# Altium schematic sheet power supply.SchDoc
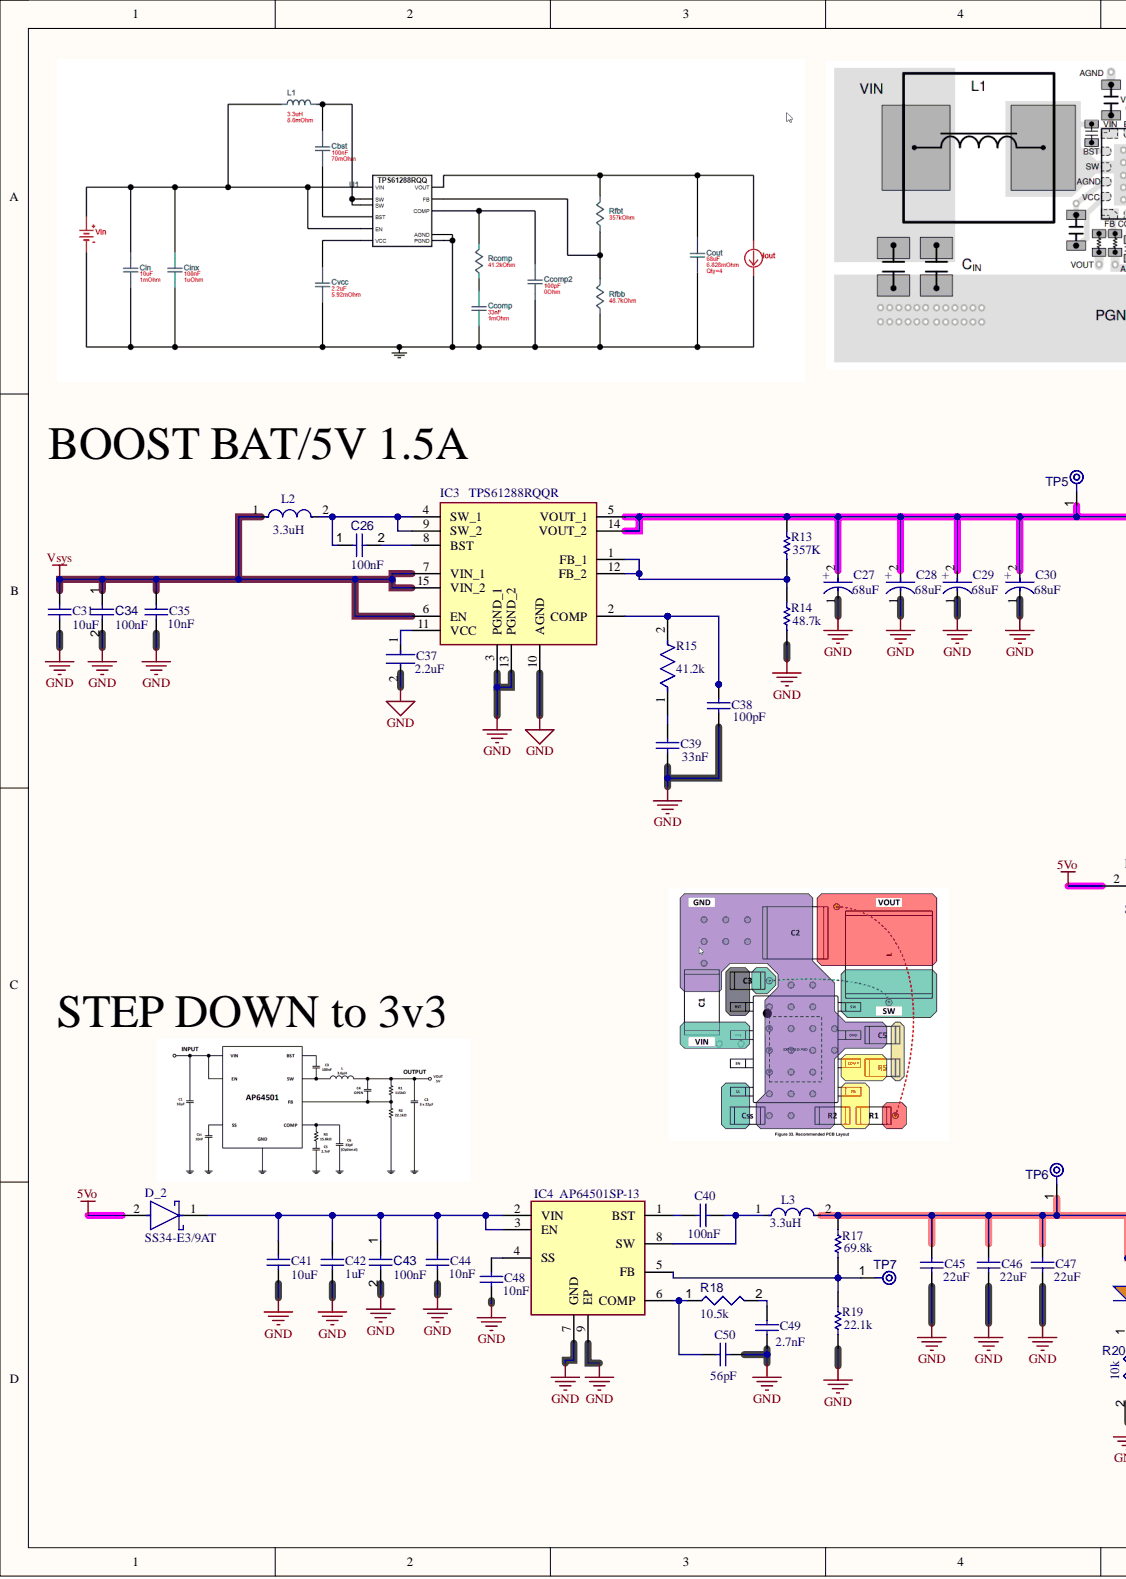
[diagram p0: power supply.SchDoc, left]
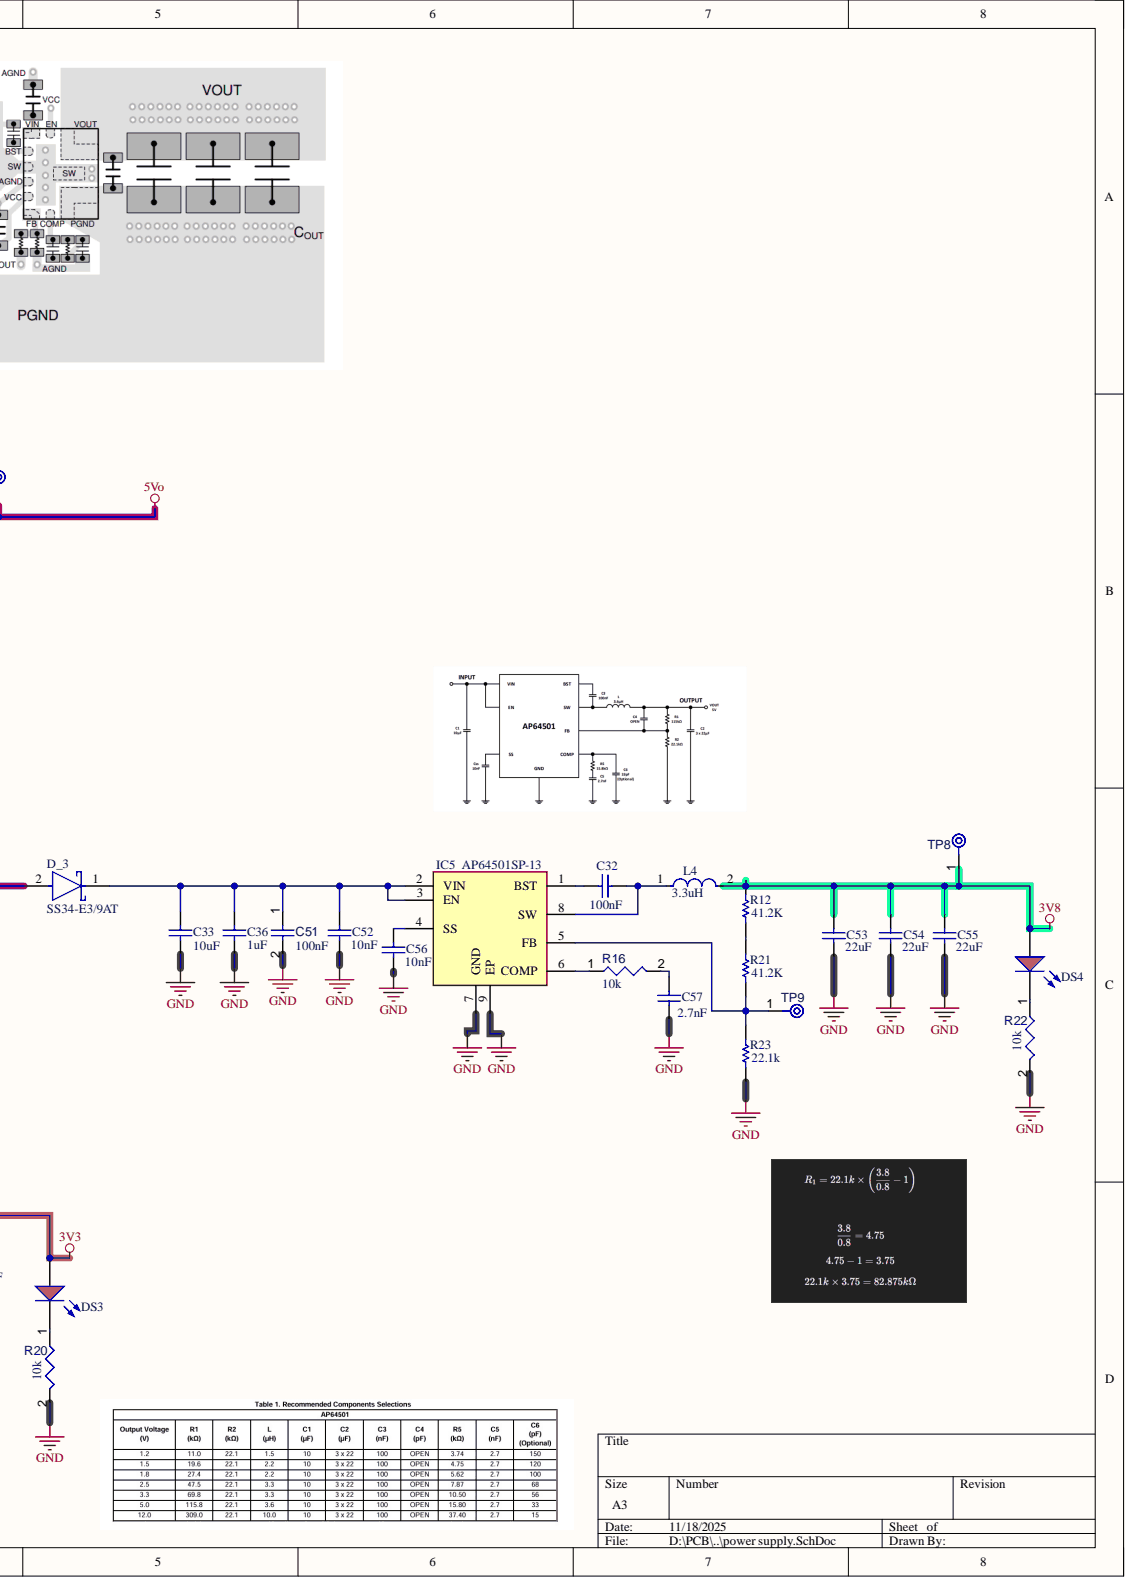
[diagram p1: power supply.SchDoc, right]

## Parts
C26  value 100nF  [CAPC1005X56X25ML10T15]  (hidden comment: Capacitor 0.1 uF +/- 5% 16 V 0402)
C27  Capacitance: 68uF  [FP-EEH-D8-MFG]  (hidden comment: EEHZA1V680XP)
C28  Capacitance: 68uF  [FP-EEH-D8-MFG]  (hidden comment: EEHZA1V680XP)
C29  Capacitance: 68uF  [FP-EEH-D8-MFG]  (hidden comment: EEHZA1V680XP)
C30  Capacitance: 68uF  [FP-EEH-D8-MFG]  (hidden comment: EEHZA1V680XP)
C31  value 10uF  [CAPC0603(1608)100_M]  (hidden comment: CAP 10uF 6.3V 0603(1608))
C32  value 100nF  [CAPC1005X55X25ML05T05]  (hidden comment: VJ0402G104KXQPW1BC)
C33  value 10uF  [CAPC0603(1608)100_M]  (hidden comment: CAP 10uF 6.3V 0603(1608))
C34  value 100nF  [CAPC1005X56X25ML10T15]  (hidden comment: Capacitor 0.1 uF +/- 5% 16 V 0402)
C35  value 10nF  [CAPC0603X33X15NL03T05]  (hidden comment: 0201ZC103JAT2A)
C36  value 1uF  [CAPC1608X90X35ML15T15]  (hidden comment: 0603YD105KAT4A)
C37  Capacitance: 2.2uF  [FP-GRM188-0_1-e0_2_0_5-IPC_A]  (hidden comment: GRM188R71A225KE15D)
C38  value 100pF  [CAPC1005X55X25LL05T05]  (hidden comment: VJ0402A101JXJCW1BC)
C39  value 33nF  [CAPC1005X56X25ML10T15]  (hidden comment: 0402ZD333KAT2A)
C40  value 100nF  [CAPC1005X55X25ML05T05]  (hidden comment: VJ0402G104KXQPW1BC)
C41  value 10uF  [CAPC0603(1608)100_M]  (hidden comment: CAP 10uF 6.3V 0603(1608))
C42  value 1uF  [CAPC1608X90X35ML15T15]  (hidden comment: 0603YD105KAT4A)
C43  value 100nF  [CAPC1005X56X25NL10T15]  (hidden comment: Capacitor 0.1 uF +/- 5% 16 V 0402)
C44  value 10nF  [CAPC0603X33X15NL03T05]  (hidden comment: 0201ZC103JAT2A)
C45  value 22uF  [CAPC1608X90X35ML15T15]  (hidden comment: 06034D226MAT2A)
C46  value 22uF  [CAPC1608X90X35ML15T15]  (hidden comment: 06034D226MAT2A)
C47  value 22uF  [CAPC1608X90X35ML15T15]  (hidden comment: 06034D226MAT2A)
C48  value 10nF  [CAPC0603X33X15NL03T05]  (hidden comment: 0201ZC103JAT2A)
C49  value 2.7nF  [CAPC1005X56X25NL10T15]  (hidden comment: 0402YC272JAT2A)
C50  value 56pF  [CAPC1005X56X25ML10T15]  (hidden comment: 04023A560KAT2A)
C51  value 100nF  [CAPC1005X56X25NL10T15]  (hidden comment: Capacitor 0.1 uF +/- 5% 16 V 0402)
C52  value 10nF  [CAPC0603X33X15NL03T05]  (hidden comment: 0201ZC103JAT2A)
C53  value 22uF  [CAPC1608X90X35ML15T15]  (hidden comment: 06034D226MAT2A)
C54  value 22uF  [CAPC1608X90X35ML15T15]  (hidden comment: 06034D226MAT2A)
C55  value 22uF  [CAPC1608X90X35ML15T15]  (hidden comment: 06034D226MAT2A)
C56  value 10nF  [CAPC0603X33X15NL03T05]  (hidden comment: 0201ZC103JAT2A)
C57  value 2.7nF  [CAPC1005X56X25NL10T15]  (hidden comment: 0402YC272JAT2A)
D_2  SS34-E3/9AT  [FP-SMC-MFG]
D_3  SS34-E3/9AT  [FP-SMC-MFG]
DS3  LTST-C190KFKT  [LTST-C190KFKT_V]  (value not drawn)
DS4  LTST-C190KFKT  [LTST-C190KFKT_V]  (value not drawn)
IC3  TPS61288RQQR  [TPS61288RQQR]
IC4  AP64501SP-13  [SOIC127P600X163-9N]
IC5  AP64501SP-13  [SOIC127P600X163-9N]
L2  Inductance: 3.3uH  [FP-MPX1D1250-MFG]  (hidden comment: MPX1D1250L3R3)
L3  Inductance: 3.3uH  [FP-MPX1D1250-MFG]  (hidden comment: MPX1D1250L3R3)
L4  Inductance: 3.3uH  [FP-MPX1D1250-MFG]  (hidden comment: MPX1D1250L3R3)
R12  value 41.2K  [RESC1608X55X25ML10T15]  (hidden comment: RC0603FR-07698KL)
R13  value 357K  [RESC1608X55X25NL10T15]  (hidden comment: RC0603FR-07357KL)
R14  value 48.7k  [RESC1608X55X25NL10T15]  (hidden comment: RC0603FR-07487KL)
R15  Resistance: 41.2k  [FP-RMCF0603-IPC_B]  (hidden comment: RMCF0603FG412K)
R16  value 10k  [RESC1608X55X25ML10T15]  (hidden comment: Resistor 10k +/-1% 0603 100 mW)
R17  value 69.8k  [RESC1608X55X25ML10T15]  (hidden comment: RC0603FR-07698KL)
R18  value 10.5k  [RESC1608X55X25ML10T15]  (hidden comment: Resistor 10k +/-1% 0603 100 mW)
R19  value 22.1k  [RESC1608X55X25ML10T15]  (hidden comment: RC0603FR-07698KL)
R20  value 10k  [RESC1608X55X25LL10T15]  (hidden comment: Resistor 10k +/-1% 0603 100 mW)
R21  value 41.2K  [RESC1608X55X25ML10T15]  (hidden comment: RC0603FR-07698KL)
R22  value 10k  [RESC1608X55X25LL10T15]  (hidden comment: Resistor 10k +/-1% 0603 100 mW)
R23  value 22.1k  [RESC1608X55X25ML10T15]  (hidden comment: RC0603FR-07698KL)
TP5  TPAD6R  [TP06R]  (value not drawn)  (no BOM)
TP6  TPAD6R  [TP06R]  (value not drawn)  (no BOM)
TP7  TPAD6R  [TP06R]  (value not drawn)  (no BOM)
TP8  TPAD6R  [TP06R]  (value not drawn)  (no BOM)
TP9  TPAD6R  [TP06R]  (value not drawn)  (no BOM)

## Nets
3V3: C45.2 C46.2 C47.2 DS3.1 L3.2 R17.2 TP6.1
3V8: C53.2 C54.2 C55.2 DS4.1 L4.2 R12.2 TP8.1
5Vo: C27.2 C28.2 C29.2 C30.2 D_2.2 D_3.2 IC3.5(VOUT_1) IC3.14(VOUT_2) R13.2 TP5.1
GND: C27.1 C28.1 C29.1 C30.1 C31.1 C33.1 C34.2 C35.1 C36.2 C37.2 C38.1 C39.1 C41.1 C42.2 C43.2 C44.1 C45.1 C46.1 C47.1 C48.1 C49.1 C50.2 C51.2 C52.1 C53.1 C54.1 C55.1 C56.1 C57.1 IC3.3(PGND_1) IC3.10(AGND) IC3.13(PGND_2) IC4.7(GND) IC4.9(EP) IC5.7(GND) IC5.9(EP) R14.1 R19.1 R20.2 R22.2 R23.1
Vsys: C31.2 C34.1 C35.2 IC3.6(EN) IC3.7(VIN_1) IC3.15(VIN_2) L2.1
NetC26_1: C26.1 IC3.4(SW_1) IC3.9(SW_2) L2.2
NetC26_2: C26.2 IC3.8(BST)
NetC32_1: C32.1 IC5.1(BST)
NetC32_2: C32.2 IC5.8(SW) L4.1
NetC33_2: C33.2 C36.1 C51.1 C52.2 D_3.1 IC5.2(VIN) IC5.3(EN)
NetC37_1: C37.1 IC3.11(VCC)
NetC38_2: C38.2 IC3.2(COMP) R15.2
NetC39_2: C39.2 R15.1
NetC40_1: C40.1 IC4.1(BST)
NetC40_2: C40.2 IC4.8(SW) L3.1
NetC41_2: C41.2 C42.1 C43.1 C44.2 D_2.1 IC4.2(VIN) IC4.3(EN)
NetC48_2: C48.2 IC4.4(SS)
NetC49_2: C49.2 R18.2
NetC50_1: C50.1 IC4.6(COMP) R18.1
NetC56_2: C56.2 IC5.4(SS)
NetC57_2: C57.2 R16.2
NetDS3_2: DS3.2 R20.1
NetDS4_2: DS4.2 R22.1
NetIC3_1: IC3.1(FB_1) IC3.12(FB_2) R13.1 R14.2
NetIC4_5: IC4.5(FB) R17.1 R19.2 TP7.1
NetIC5_5: IC5.5(FB) R21.1 R23.2 TP9.1
NetIC5_6: IC5.6(COMP) R16.1
NetR12_1: R12.1 R21.2

## Library data of the parts
C26 C34 C43 C51 = CMP-001-00001-6: Chip Capacitor, 0.1 uF, +/- 5%, 16 V, 0402 (1005 Metric); Capacitor Type: Ceramic; Voltage Rating: 16V; Case/Package: 0402; Component Kind: Standard; Max Operating Temperature: 125°C; Min Operating Temperature: -55°C; Mounting Technology: SM; Pins: 2; RoHS Compliant: True; Tolerance: 5%; Library Name: Carlos Christopher Carbajal Jordán
C27-C30 = CMP-05428-001211-1: Conductive Polymer Hybrid Aluminum Electrolytic Capacitors 68uF ±20% 35V RADIAL SMT 6.3x7.7mm; ESR (Equivalent Series Resistance): 35mR; Lead Diameter: 0.65mm; Leakage Current: 23.8uA; Life (Hours): 5000Hour; Polarity: Polar; Ripple Current: 2A; Case/Package: Radial; Component Kind: Standard; Construction: Cylindrical; Diameter: 6.3mm; Height: 7.7mm; Height - Seated (Max): 0.315inch; Lead Free: Lead Free; Lead Pitch: 1.8mm; Length: 7.7mm; Library Name: Altium Content Vault; Material: Polymer; Max Operating Temperature: 105°C; Min Operating Temperature: -55°C; Mount: Surface Mount; Number of Pins: 8; Package Quantity: 900; Packaging: Tape and Reel; Radiation Hardening: No; Ratings: AEC-Q200; REACH SVHC: No SVHC; Ripple Current (AC): 2A; Series: ZA; Technology: HYBRID; Termination: SMD/SMT; Tolerance: 20%; Voltage: 35V; Voltage Rating: 35V; Voltage Rating (DC): 35V
C31 C33 C41 = CMP-1035-02796-1: CAP 10uF 6.3V ±10% 0603 (1608 Metric) Thickness 1mm SMD; Case-EIA: 0603; Case-Metric: 1608; Tolerance: Â±10%; Component Kind: Standard; Library Name: Altium Content Vault; Max Thickness: 1 mm; Rated Voltage: 6.3 V; Technology: SMT
C32 C40 = CMP-2008-01360-1: Manufacturer: Vitramon; Component Kind: Standard; Library Name: Altium Content Vault; Part Number: VJ0402G104KXQPW1BC
C35 C44 C48 C52 C56 = CMP-2010-00529-1: Manufacturer: AVX Interconnect / Elco; Component Kind: Standard; Library Name: Altium Content Vault; Part Number: 0201ZC103JAT2A
C36 C42 = CMP-2006-04533-1: Manufacturer: AVX Interconnect / Elco; Component Kind: Standard; Library Name: Altium Content Vault; Part Number: 0603YD105KAT4A
C37 = CMP-2006-02836-2: Chip Multilayer Ceramic Capacitors for General Purpose, 0603, 2.2uF, X7R, 15%, 10%, 10V; Case Code (Imperial): 0603; Case Code (Metric): 1608; Case/Package: 0603; Component Kind: Standard; Depth: 0.8mm; Dielectric: X7R; Height: 0.8mm; Lead Free: Lead Free; Length: 1.6mm; Library Name: Altium Content Vault; Material: Ceramic; Max Operating Temperature: 125°C; Min Operating Temperature: -55°C; Mount: Surface Mount; Number of Pins: 2; Package Quantity: 1; Packaging: Cut Tape; REACH SVHC: No SVHC; Termination: SMD/SMT; Thickness: 0.035inch; Tolerance: 10%; Voltage: 10V; Voltage Rating: 10V; Voltage Rating (DC): 10V; Weight: 7.1E-05oz; Width: 0.031inch
C38 = CMP-2008-01280-1: Manufacturer: Vitramon; Component Kind: Standard; Library Name: Altium Content Vault; Part Number: VJ0402A101JXJCW1BC
C39 = CMP-2008-02891-1: Manufacturer: AVX Interconnect / Elco; Component Kind: Standard; Library Name: Altium Content Vault; Part Number: 0402ZD333KAT2A
C45-C47 C53-C55 = CMP-2006-04205-1: Manufacturer: AVX Interconnect / Elco; Component Kind: Standard; Library Name: Altium Content Vault; Part Number: 06034D226MAT2A
C49 C57 = CMP-2008-02966-1: Manufacturer: AVX Interconnect / Elco; Component Kind: Standard; Library Name: Altium Content Vault; Part Number: 0402YC272JAT2A
C50 = CMP-2008-02504-1: Manufacturer: AVX Interconnect / Elco; Component Kind: Standard; Library Name: Altium Content Vault; Part Number: 04023A560KAT2A
D_2 D_3 = CMP-02539-000066-1: DIODE SCHOTTKY 40V 3A DO214AB; Element Configuration: Single; Forward Voltage: 500mV; Max Reverse Current: 500uA; Max Surge Current: 100A; Average Rectified Current: 3A; Case/Package: SMC; Component Kind: Standard; Forward Current: 3A; Library Name: Altium Content Vault; Max Operating Temperature: 125°C; Max Repetitive Reverse Voltage (Vrrm): 40V; Max Reverse Leakage Current: 500uA; Max Reverse Voltage (DC): 40V; Min Operating Temperature: -55°C; Mount: Surface Mount; Number of Pins: 2; Package Quantity: 3500; Packaging: Tape and Reel; Peak Non-Repetitive Surge Current: 100A; Radiation Hardening: No; RoHS Compliant: Yes; Series: -
DS3 DS4 = CMP-007-00023-2: LED Uni-Color Orange, 75 mW, 30 mA, -35 to 85 degC, 2-Pin SMD, RoHS, Tape and Reel; Manufacturer: Lite-On; Forward Current: 20mA; Forward Voltage: 2V; Lens Style: Diffused; Luminous Intensity: 90mcd; Power: 75mW; Viewing Angle: 130degrees; Case/Package: 0603; Colour: Orange; Component Kind: Standard; Datasheet URL: http://optoelectronics.liteon.com/upload/download/DS-22-99-0186/S_110_LTST-C190KFKT.pdf; Datasheet Version: Rev. I, 09/2011; Illumination Colour: Orange; Manufacturer URL: http://www.us.liteon.com/; Max Operating Temperature: 85°C; Min Operating Temperature: -55°C; Mounting Technology: SM; Nominal Vf: 2V; Package Description: 2-Pin Surface Mount Device, Body 1.6 x 0.8 mm; Package Quantity: 3000; Package Reference: C190KFKT; Packaging: TapeandReel; Pins: 2; RoHS Compliant: True; Library Name: Carlos Christopher Carbajal Jordán
IC3 = TPS61288RQQR: Integrated Circuit; Manufacturer_Name: Texas Instruments; Arrow Part Number: TPS61288RQQR; Arrow Price/Stock: https://www.arrow.com/en/products/tps61288rqqr/texas-instruments?utm_currency=USD&region=europe; Component Kind: Standard; Datasheet Link: https://www.ti.com/lit/ds/symlink/tps61288.pdf?ts=1609351344517&ref_url=https%253A%252F%252Fwww.ti.com%252Fpower-management%252Fnon-isolated-dc-dc-switching-regulators%252Fstep-up-boost%252Fboost-converters-integrated-switch%252Fproducts.html; Height: 1mm; Library Name: TPS61288RQQR.SchLib; Manufacturer_Part_Number: TPS61288RQQR; Mouser Part Number: 595-TPS61288RQQR; Mouser Price/Stock: https://www.mouser.co.uk/ProductDetail/Texas-Instruments/TPS61288RQQR?qs=eP2BKZSCXI7ZX8saYJkaIw%3D%3D
IC4 IC5 = AP64501SP-13: Integrated Circuit; Manufacturer_Name: Diodes Incorporated; Arrow Part Number: AP64501SP-13; Arrow Price/Stock: https://www.arrow.com/en/products/ap64501sp-13/diodes-incorporated?utm_currency=USD&region=nac; Component Kind: Standard; Datasheet Link: https://www.diodes.com//assets/Datasheets/AP64501.pdf; Height: 1.63mm; Library Name: AP64501.SchLib; Manufacturer_Part_Number: AP64501SP-13; Mouser Part Number: 621-AP64501SP-13; Mouser Price/Stock: https://www.mouser.co.uk/ProductDetail/Diodes-Incorporated/AP64501SP-13?qs=P1JMDcb91o7FS0GU%252BW7TIQ%3D%3D
L2-L4 = CMP-03027-000009-1: FIXED IND 3.3UH 16.8A 7.4MOHM SM; Core Material: Metal; DC Resistance (DCR): 7.4mR; Saturation Current: 22A; Self Resonant Frequency: 17MHz; Shielding: Shielded; Test Frequency: 100kHz; Tolerance: 20%; Case/Package: Nonstandard; Component Kind: Standard; Library Name: Altium Content Vault; Max Operating Temperature: 155°C; Min Operating Temperature: -55°C; Mount: Surface Mount; RoHS Compliant: Yes; Series: METCOM MPX
R12 R17 R21 = CMP-2000-04113-1: Manufacturer: Yageo; Part Number: C3016381; Component Kind: Standard; Library Name: Altium Content Vault
R13 = CMP-2000-04022-1: Manufacturer: Yageo; Component Kind: Standard; Library Name: Altium Content Vault; Part Number: RC0603FR-07357KL
R14 = CMP-2000-04060-1: Manufacturer: Yageo; Component Kind: Standard; Library Name: Altium Content Vault; Part Number: RC0603FR-07487KL
R15 = CMP-26527-004815-1: 412kΩ ±1% 0.1W 0603 Thick Film Chip Resistor AEC-Q200 compliant; Temperature Coefficient: 100ppm/°C; Case/Package: 0603; Component Kind: Standard; Contact Plating: Tin; Library Name: Altium Content Vault; Max Operating Temperature: 155°C; Min Operating Temperature: -55°C; Mount: Surface Mount; Packaging: Tape and Reel; Radiation Hardening: No; Resistor Type: Thick Film; RoHS Compliant: Yes; Tolerance: 1%
R16 R18 R20 R22 = CMP-009-00017-5: Chip Resistor, 10 KOhm, +/- 1%, 01 W, -55 to 155 degC, 0603 (1608 Metric); Power: 100mW; Voltage Rating: 50V; Case/Package: 0603; Component Kind: Standard; Max Operating Temperature: 155°C; Min Operating Temperature: -55°C; Mounting Technology: SM; Pins: 2; RoHS Compliant: True; Tolerance: 1%; Library Name: Carlos Christopher Carbajal Jordán
R19 R23 = CMP-2000-04113-1: Manufacturer: Yageo; Part Number: C2960862; Component Kind: Standard; Library Name: Altium Content Vault
TP5-TP9 = CMP-00028-00002-2: 0.6mm round; Pins: 1; Library Name: Carlos Christopher Carbajal Jordán
